# Altium schematic sheet 00-Overview.SchDoc(00-Overview)
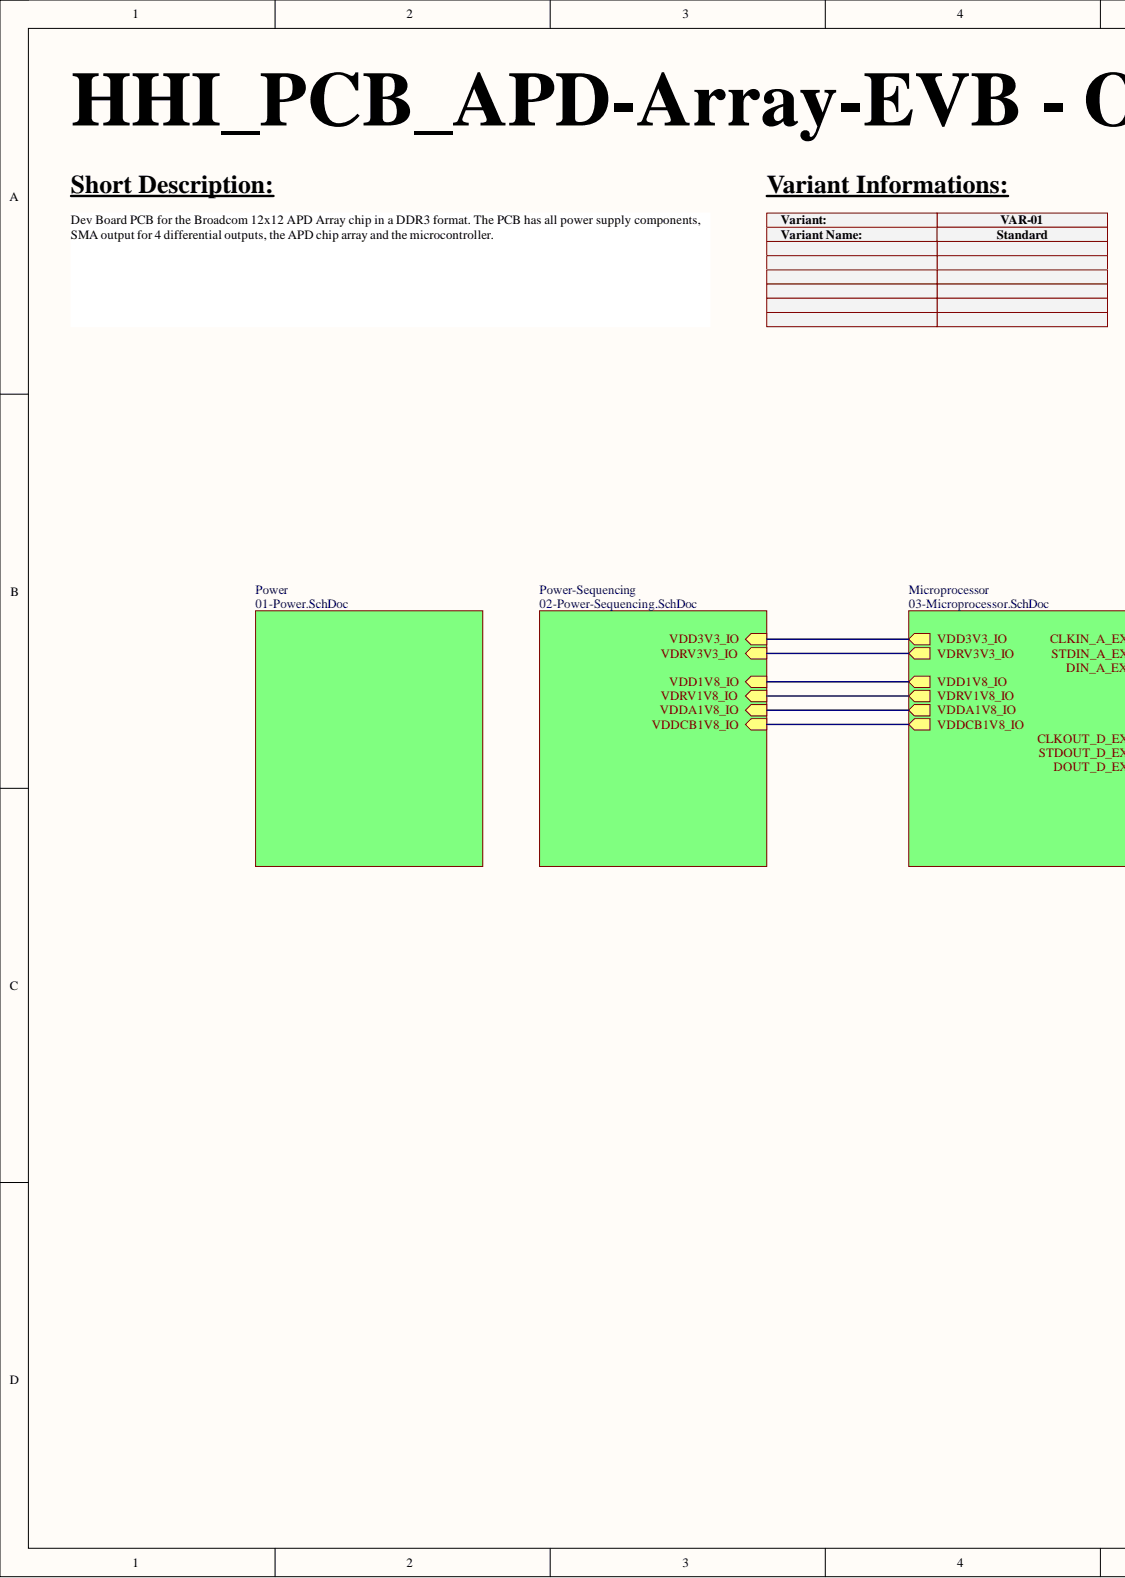
[diagram p0: 00-Overview.SchDoc, left]
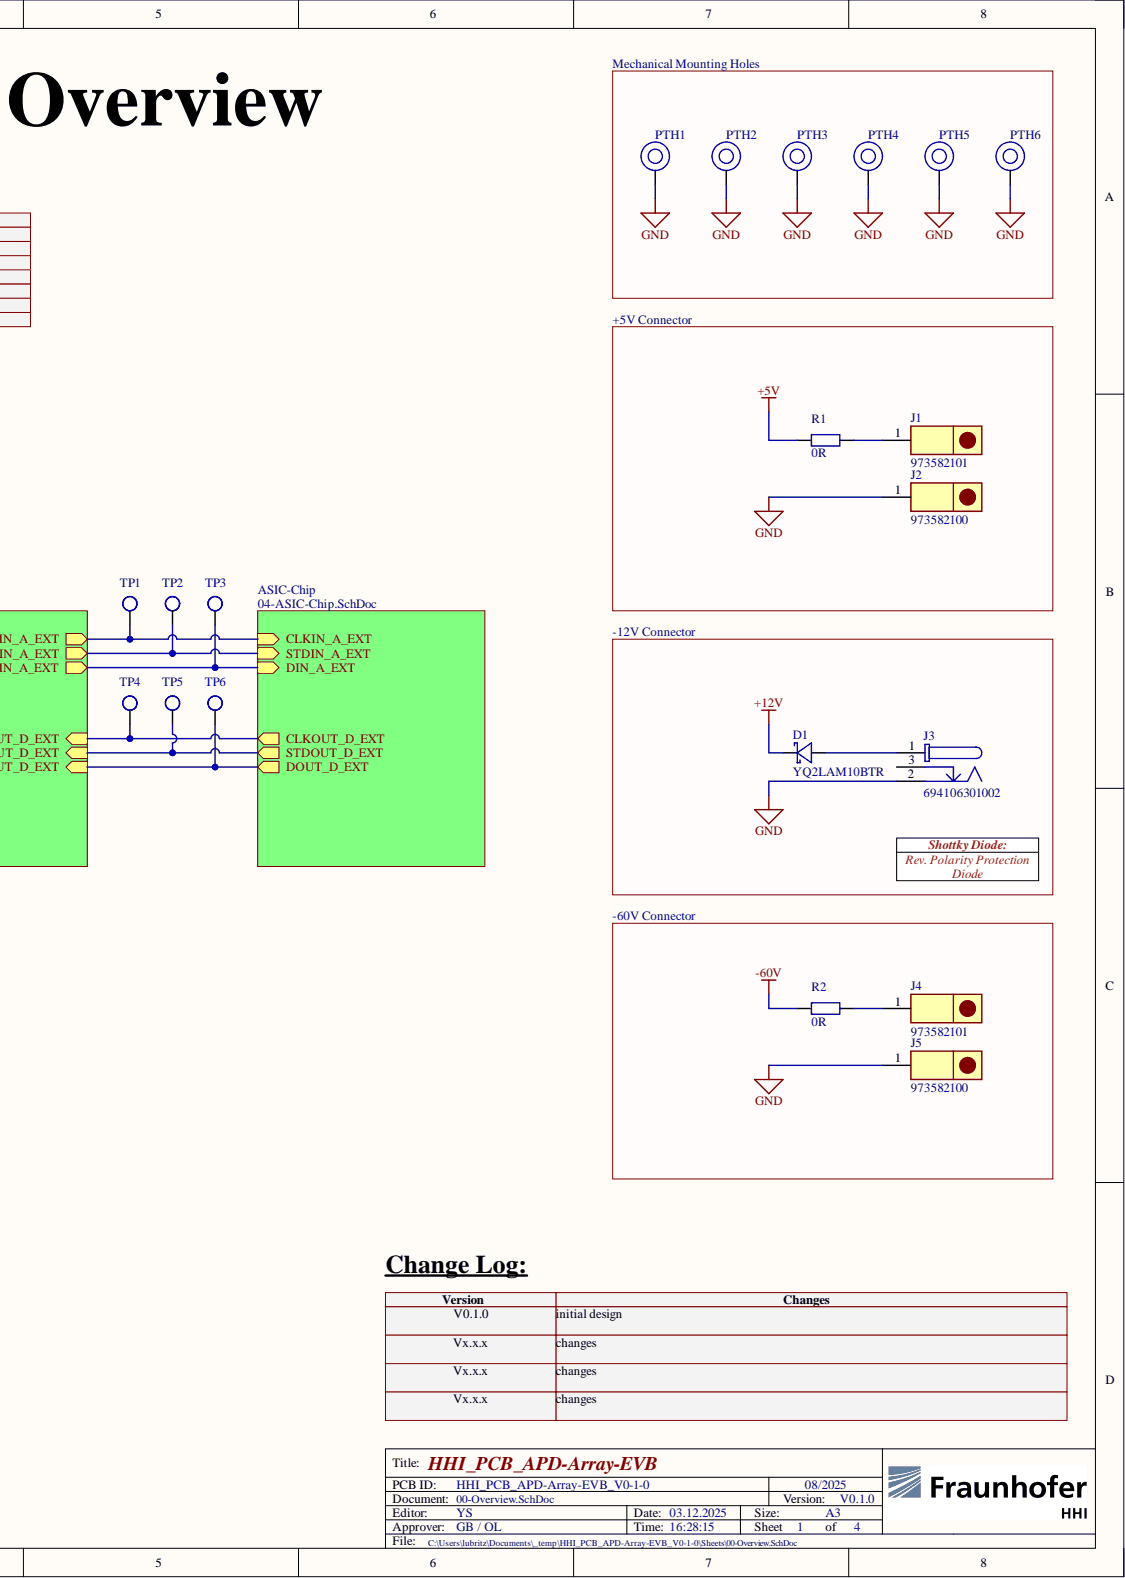
[diagram p1: 00-Overview.SchDoc, right]

## Parts
D1  YQ2LAM10BTR  [DIO_SOD-128_SODFL470X95-2N]
J1  973582101  [CON_FEMALE_973582101]
J2  973582100  [CON_FEMALE_973582100]
J3  694106301002  [CON_MALE_694106301002]
J4  973582101  [CON_FEMALE_973582101]
J5  973582100  [CON_FEMALE_973582100]
PTH1  3.2 mm / 6mm  [MISC_MH_M3_3,2mm_6mm]  (value not drawn)  (no BOM)
PTH2  3.2 mm / 6mm  [MISC_MH_M3_3,2mm_6mm]  (value not drawn)  (no BOM)
PTH3  3.2 mm / 6mm  [MISC_MH_M3_3,2mm_6mm]  (value not drawn)  (no BOM)
PTH4  3.2 mm / 6mm  [MISC_MH_M3_3,2mm_6mm]  (value not drawn)  (no BOM)
PTH5  3.2 mm / 6mm  [MISC_MH_M3_3,2mm_6mm]  (value not drawn)  (no BOM)
PTH6  3.2 mm / 6mm  [MISC_MH_M3_3,2mm_6mm]  (value not drawn)  (no BOM)
R1  0R  [RES_RESC1608_0603_N]
R2  0R  [RES_RESC1608_0603_N]
TP1  0,8 mm / 1,4 mm  [MISC_TP_THT_RD_0,8MM_1,4MM]  (value not drawn)  (no BOM)
TP2  0,8 mm / 1,4 mm  [MISC_TP_THT_RD_0,8MM_1,4MM]  (value not drawn)  (no BOM)
TP3  0,8 mm / 1,4 mm  [MISC_TP_THT_RD_0,8MM_1,4MM]  (value not drawn)  (no BOM)
TP4  0,8 mm / 1,4 mm  [MISC_TP_THT_RD_0,8MM_1,4MM]  (value not drawn)  (no BOM)
TP5  0,8 mm / 1,4 mm  [MISC_TP_THT_RD_0,8MM_1,4MM]  (value not drawn)  (no BOM)
TP6  0,8 mm / 1,4 mm  [MISC_TP_THT_RD_0,8MM_1,4MM]  (value not drawn)  (no BOM)

## Nets
+5V: R1.1
+12V: D1.1
-60V: R2.1
GND: J2.1 J3.2 J5.1 PTH1.1 PTH2.1 PTH3.1 PTH4.1 PTH5.1 PTH6.1
NetD1_2: D1.2 J3.1
NetJ1_1: J1.1 R1.2
NetJ3_3: J3.3
NetJ4_1: J4.1 R2.2
NetTP1_1: TP1.1
NetTP2_1: TP2.1
NetTP3_1: TP3.1
NetTP4_1: TP4.1
NetTP5_1: TP5.1
NetTP6_1: TP6.1
VDD1V8_IO:
VDD3V3_IO:
VDDA1V8_IO:
VDDCB1V8_IO:
VDRV1V8_IO:
VDRV3V3_IO:

## Library data of the parts
D1 = DIODE0014: DIODE / schottky / YQ2LAM10BTR / 0,6 V / 100 V / -; Mfr: Rohm; Breakdown Voltage: 100 V; Current: 2 A; Database Table Name: diode$; Dis: Mouser; DisNo: 755-YQ2LAM10BTR; Editor: YS; ProvidedBy: EMS; Voltage: 0,6 V; Component Kind: Standard; DesignItemID: DIODE0014; Mfr-Link: https://www.rohm.de/products/diodes/schottky-barrier-diodes/high-efficiency/yq2lam10b-product; MfrNo: YQ2LAM10BTR; Package: SOD-128; Pins: 2; Power: -; Status: InProgress; TempHigh: 175 °C; TempLow: -55 °C; Type: schottky; Value: YQ2LAM10BTR; Library Name: Altium-Lib-HHI.DbLib
J1 J4 = CON0024: CON / - x - / female / Banana Jack 4mm / Red / 973582101; Mfr: Altech; Assembly: THT; Current: 16 A; Database Table Name: connectors$; Dis: Mouser; DisNo: 845-973582101; Editor: YS; Plating: Tin; ProvidedBy: HHI; Voltage: 60 VDC; Component Kind: Standard; DesignItemID: CON0024; Gender: female; Library Name: Altium-Lib-HHI.DbLib; Mfr-Link: https://legacy.altechcorp.com/test-equipment/PDFs/TestMeasurement59.pdf; MfrNo: 973582101; Pins: 1; Pins per Row: -; Pitch: -; Rows: -; Status: Done; TempHigh: 70 °C; TempLow: -25 °C; Type: Banana Jack 4mm / Red; Value: 973582101
J2 J5 = CON0025: CON / - x - / female / Banana Jack 4mm / Black / 973582100; Mfr: Altech; Assembly: THT; Current: 16 A; Database Table Name: connectors$; Dis: Mouser; DisNo: 845-973582100; Editor: YS; Plating: Tin; ProvidedBy: HHI; Voltage: 60 VDC; Component Kind: Standard; DesignItemID: CON0025; Gender: female; Library Name: Altium-Lib-HHI.DbLib; Mfr-Link: https://legacy.altechcorp.com/test-equipment/PDFs/TestMeasurement59.pdf; MfrNo: 973582100; Pins: 1; Pins per Row: -; Pitch: -; Rows: -; Status: Done; TempHigh: 70 °C; TempLow: -25 °C; Type: Banana Jack 4mm / Black; Value: 973582100
J3 = CON0014: CON / - x - / male / Power Jack / 694106301002; Manufacturer: Wurth Elektronik; Mfr: Würth Elektronik; Assembly: THT; Current: 5 A; Database Table Name: connectors$; Dis: Mouser; DisNo: 710-694106301002; Editor: OL; Match Code: WR-DC; Opening Diameter: 6.4mm; Plating: Gold; Plug Inner Diameter: 2.05mm; Plug Outer Diameter: 5.5mm; ProvidedBy: HHI; Rated Current: 5A; Voltage: 30 V; Working Voltage: 24V; Category: DC Power Jack Connector; Component Kind: Standard; ComponentLink1Description: Website Link; ComponentLink1URL: https://www.we-online.com/redexpert/article/694106301002?ad; ComponentLink2Description: Datasheet Link; ComponentLink2URL: https://www.we-online.com/redexpert/spec/694106301002?ad; DesignItemID: CON0014; Gender: male; Library Name: Altium-Lib-HHI.DbLib; Manufacturer Part Number: 694106301002; Mfr-Link: https://www.we-online.com/de/components/products/DC_RIGHT_ANGLED_6_4_69410X301002?sq=694106301002#694106301002; MfrNo: 694106301002; Mount: Through Hole; Operating Temperature Max: 85°C; Operating Temperature Min: -40°C; Orientation: Right Angled; Packaging: Bag; Pins: 3; Pins per Row: -; Pitch: -; Rows: -; Status: Done; TempHigh: 85 °C; TempLow: -40 °C; Type: Power Jack; Value: 694106301002
PTH1-PTH6 = MISC0009: MISC / mount-hole / 3.2 mm / 6mm / round / THT; Database Table Name: misc$; Editor: OL; AdditionalDescription: round / THT; DesignItemID: MISC0009; Dis: -; DisNo: -; Frequency: -; MaxCurrent: -; Mfr: -; Mfr-Link: -; MfrNo: -; Package: M3; Pins: -; ProvidedBy: -; Status: Done; TempHigh: -; TempLow: -; Type: mount-hole; Value: 3.2 mm / 6mm; Voltage: -; Library Name: Altium-Lib-HHI.DbLib
R1 R2 = RES0072: RES / 0R / 0603 / < 20mR / 75 V / 210 mW; Mfr: Vishay; MfrNo: MCT0603MZ0000ZP500; Database Table Name: resistor$; Dis: Mouser; DisNo: 594-MCT0603MZ0000ZP5; Editor: OL; Power: 210 mW; ProvidedBy: EMS; Tolerance: < 20mR; Voltage: 75 V; Component Kind: Standard; DesignItemID: RES0072; Mfr-Link: https://www.vishay.com/en/product/28952/; Package: 0603; Pins: 2; Status: Done; TempHigh: 175 °C; TempLow: -55 °C; Type: jumper; Value: 0R; Library Name: Altium-Lib-HHI.DbLib
TP1-TP6 = MISC0008: MISC / test-point / 0,8 mm / 1,4 mm / round / THT; Database Table Name: misc$; Editor: OL; AdditionalDescription: round / THT; DesignItemID: MISC0008; Dis: -; DisNo: -; Frequency: -; MaxCurrent: -; Mfr: -; Mfr-Link: -; MfrNo: -; Package: -; Pins: -; ProvidedBy: -; Status: Done; TempHigh: -; TempLow: -; Type: test-point; Value: 0,8 mm / 1,4 mm; Voltage: -; Library Name: Altium-Lib-HHI.DbLib
